# Altium schematic sheet SENSOR_VENTO_V2.SchDoc(SENSOR_VENTO_V2)
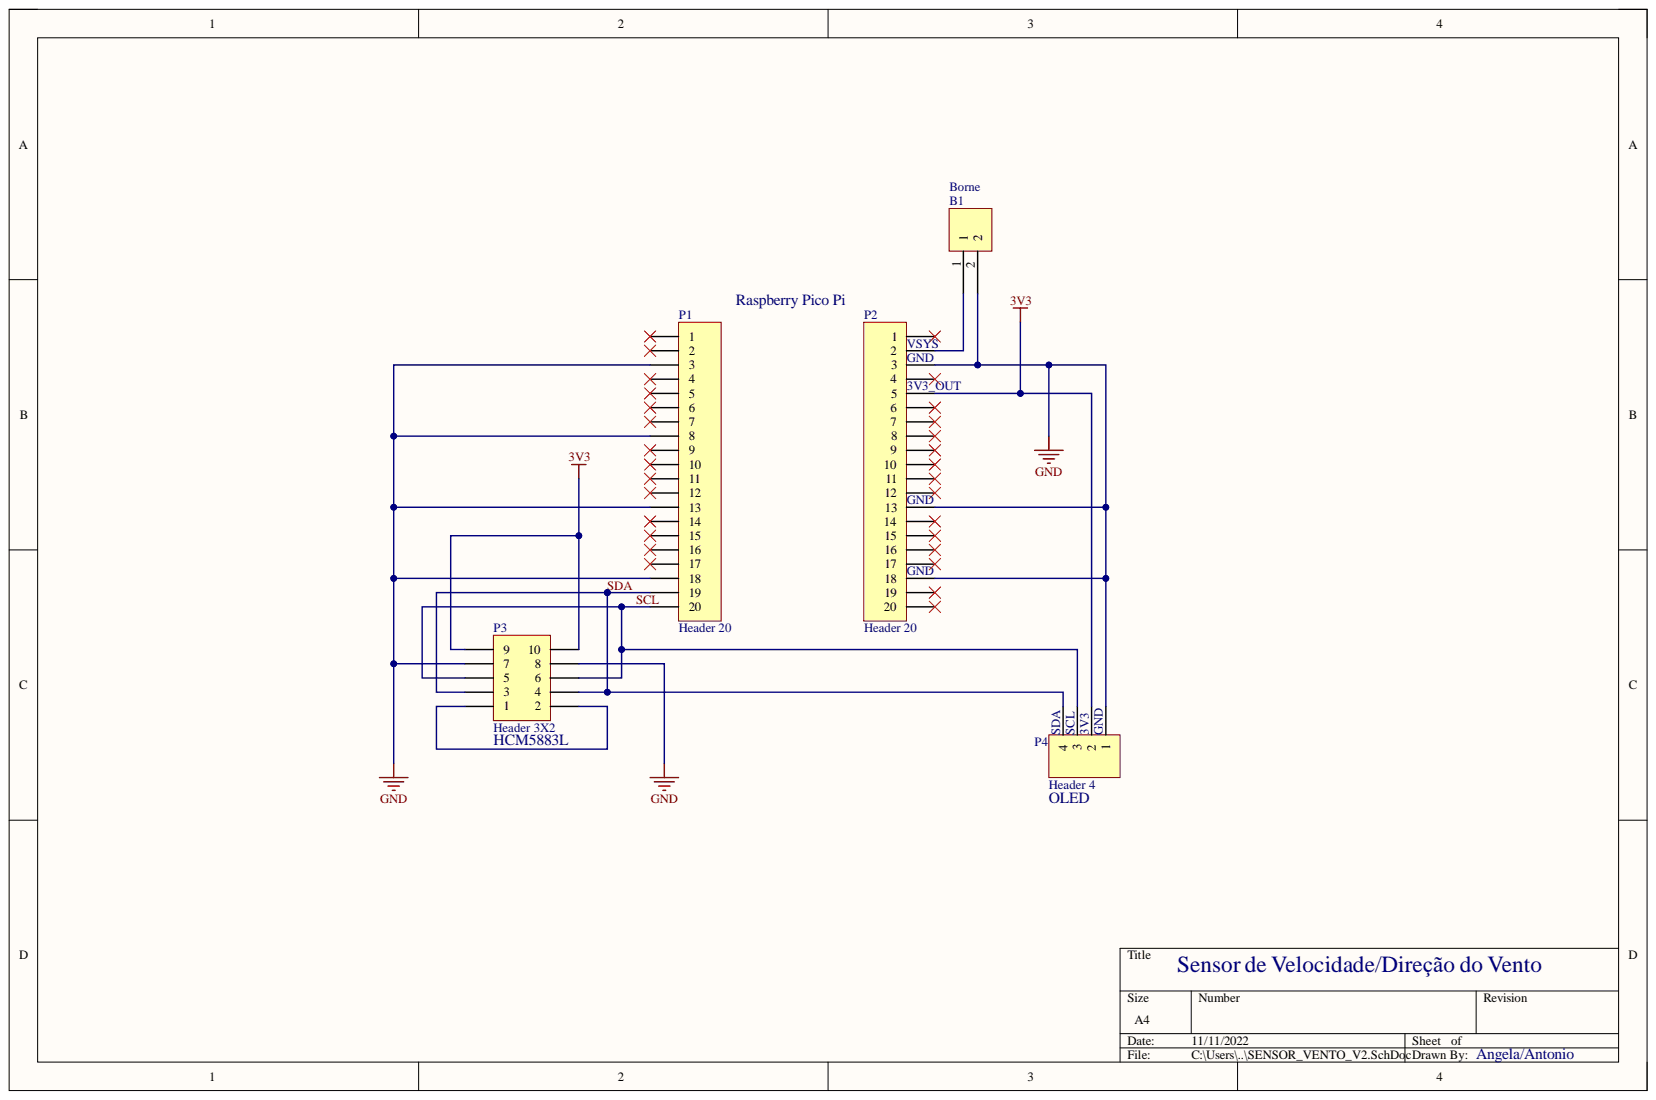
[diagram p0: SENSOR_VENTO_V2.SchDoc]

## Parts
B1  Borne  [Borne2T]
P1  Header 20  [HDR1X20]
P2  Header 20  [HDR1X20]
P3  Header 3X2  [IDC_2X5]
P4  Header 4  [HDR1X4]

## Nets
3V3: P2.5 P3.9 P3.10 P4.2
GND: B1.2 P1.3 P1.8 P1.13 P1.18 P2.3 P2.13 P2.18 P3.7 P3.8 P4.1
SCL: P1.20 P3.5 P3.6 P4.3
SDA: P1.19 P3.3 P3.4 P4.4
NetB1_1: B1.1 P2.2
NetP3_1: P3.1 P3.2

Not connected: P1 1 2 4 5 6 7 9 10 11 12 14 15 16 17; P2 1 4 6 7 8 9 10 11 12 14 15 16 17 19 20

## Library data of the parts
B1 = B: Component Kind: Standard; Library Name: CLNLITS18ARFD40.IntLib
P1 P2 = Header 20: Header, 20-Pin; Component Kind: Standard; LatestRevisionDate: 17-Jul-2002; LatestRevisionNote: Re-released for DXP Platform.; Library Name: Miscellaneous Connectors.IntLib; Signal Integrity: Connector; Publisher: Altium Limited
P3 = IDC_2X5: Header, 3-Pin, Dual row; Component Kind: Standard; LatestRevisionDate: 17-Jul-2002; LatestRevisionNote: Re-released for DXP Platform.; Library Name: IDC_2X5.SCHLIB; Publisher: Altium Limited
P4 = Header 4: Header, 4-Pin; Component Kind: Standard; LatestRevisionDate: 17-Jul-2002; LatestRevisionNote: Re-released for DXP Platform.; Library Name: Miscellaneous Connectors.IntLib; Signal Integrity: Connector; Publisher: Altium Limited
